# Altium schematic sheet CPU.SchDoc
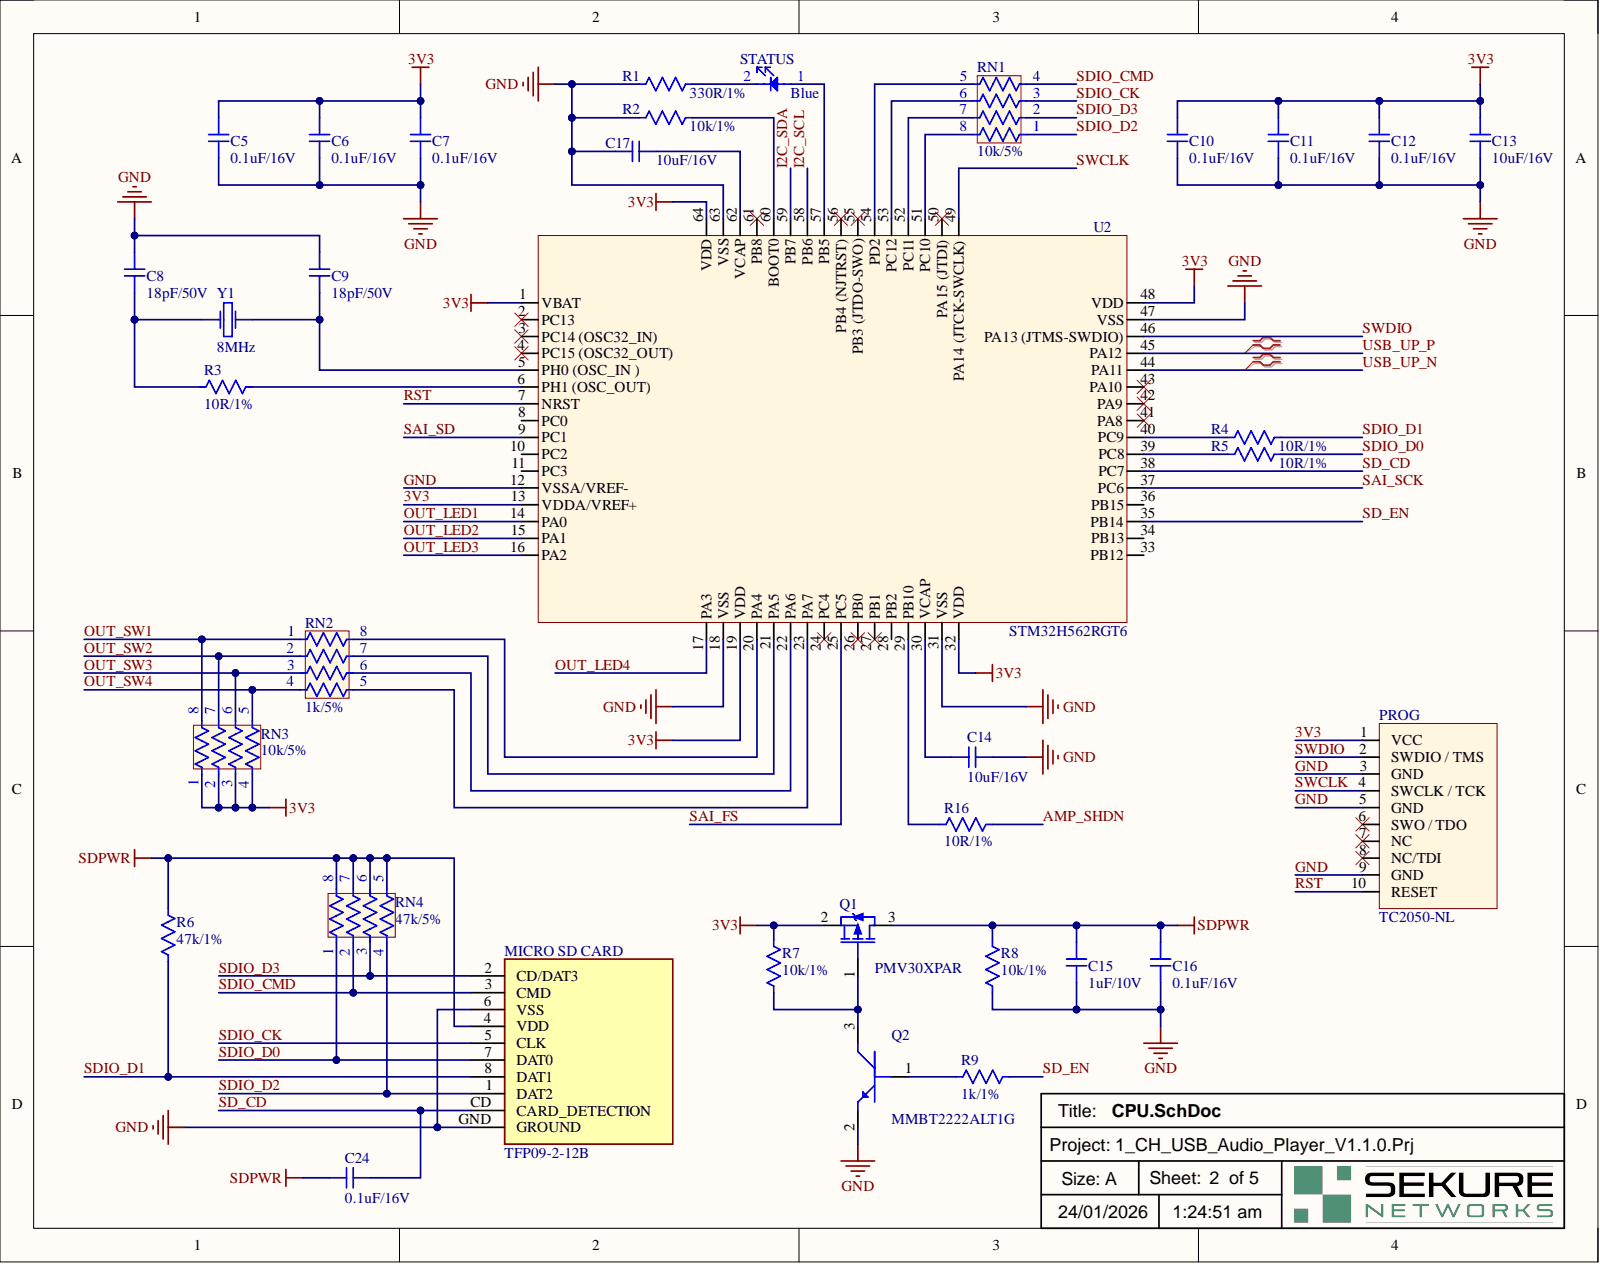
[diagram p0: CPU.SchDoc]

## Parts
C5  value 0.1uF/16V  [CAP 0603]  (hidden comment: Ceramic Capacitor)
C6  value 0.1uF/16V  [CAP 0603]  (hidden comment: Ceramic Capacitor)
C7  value 0.1uF/16V  [CAP 0603]  (hidden comment: Ceramic Capacitor)
C8  value 18pF/50V  [CAP 0603]  (hidden comment: Ceramic Capacitor)
C9  value 18pF/50V  [CAP 0603]  (hidden comment: Ceramic Capacitor)
C10  value 0.1uF/16V  [CAP 0603]  (hidden comment: Ceramic Capacitor)
C11  value 0.1uF/16V  [CAP 0603]  (hidden comment: Ceramic Capacitor)
C12  value 0.1uF/16V  [CAP 0603]  (hidden comment: Ceramic Capacitor)
C13  value 10uF/16V  [Cap 0805]  (hidden comment: Ceramic Capacitor)
C14  value 10uF/16V  [Cap 0805]  (hidden comment: Ceramic Capacitor)
C15  value 1uF/10V  [CAP 0603]  (hidden comment: Ceramic Capacitor)
C16  value 0.1uF/16V  [CAP 0603]  (hidden comment: Ceramic Capacitor)
C17  value 10uF/16V  [Cap 0805]  (hidden comment: Ceramic Capacitor)
C24  value 0.1uF/16V  [CAP 0603]  (hidden comment: Ceramic Capacitor)
MICRO_SD_CARD  value TFP09-2-12B  [MR01A-01211]  (hidden comment: SD Card Slot)
PROG  value TC2050-NL  [TC2050_full]  (hidden comment: Programming Connector)
Q1  value PMV30XPAR  [SOT23]  (hidden comment: Mosfet)
Q2  value MMBT2222ALT1G  [SOT23]  (hidden comment: Transistor)
R1  value 330R/1%  [RES 0603]  (hidden comment: Resistor)
R2  value 10k/1%  [RES 0603]  (hidden comment: Resistor)
R3  value 10R/1%  [RES 0603]  (hidden comment: Resistor)
R4  value 10R/1%  [RES 0603]  (hidden comment: Resistor)
R5  value 10R/1%  [RES 0603]  (hidden comment: Resistor)
R6  value 47k/1%  [RES 0603]  (hidden comment: Resistor)
R7  value 10k/1%  [RES 0603]  (hidden comment: Resistor)
R8  value 10k/1%  [RES 0603]  (hidden comment: Resistor)
R9  value 1k/1%  [RES 0603]  (hidden comment: Resistor)
R16  value 10R/1%  [RES 0603]  (hidden comment: Resistor)
RN1  value 10k/5%  [1206 (3216 Metric)]  (hidden comment: Resistor Network)
RN2  value 1k/5%  [1206 (3216 Metric)]  (hidden comment: Resistor Network)
RN3  value 10k/5%  [1206 (3216 Metric)]  (hidden comment: Resistor Network)
RN4  value 47k/5%  [1206 (3216 Metric)]  (hidden comment: Resistor Array)
STATUS  value Blue  Color: Blue  [APT1608SURCK]  (hidden comment: LED)
U2  value STM32H562RGT6  [LQFP64-1000X1000X160-64N]  (hidden comment: Microcontroller)
Y1  value 8MHz  Frequency: 8MHz  [ECS-80-18-30-JGN-TR]  (hidden comment: Crystal)

## Nets
SDPWR: C15.2 C16.1 C24.1 MICRO_SD_CARD.4(VDD) Q1.3 R6.1 R8.2 RN4.5 RN4.6 RN4.7 RN4.8
3V3: C5.1 C6.1 C7.1 C10.1 C11.1 C12.1 C13.1 PROG.1(VCC) Q1.2 R7.2 RN3.1 RN3.2 RN3.3 RN3.4 U2.1(VBAT) U2.13(VDDA/VREF+) U2.19(VDD) U2.32(VDD) U2.48(VDD) U2.64(VDD)
AMP_SHDN: R16.2
GND: C5.2 C6.2 C7.2 C8.1 C9.1 C10.2 C11.2 C12.2 C13.2 C14.2 C15.1 C16.2 C17.2 MICRO_SD_CARD.6(VSS) MICRO_SD_CARD.GND(GROUND) PROG.3(GND) PROG.5(GND) PROG.9(GND) Q2.2 R1.2 R2.2 R8.1 U2.12(VSSA/VREF-) U2.18(VSS) U2.31(VSS) U2.47(VSS) U2.63(VSS)
I2C_SCL: U2.58(PB6)
I2C_SDA: U2.59(PB7)
OUT_LED1: U2.14(PA0)
OUT_LED2: U2.15(PA1)
OUT_LED3: U2.16(PA2)
OUT_LED4: U2.17(PA3)
OUT_SW1: RN2.1 RN3.8
OUT_SW2: RN2.2 RN3.7
OUT_SW3: RN2.3 RN3.6
OUT_SW4: RN2.4 RN3.5
RST: PROG.10(RESET) U2.7(NRST)
SAI_FS: U2.25(PC5)
SAI_SCK: U2.37(PC6)
SAI_SD: U2.9(PC1)
SD_CD: C24.2 MICRO_SD_CARD.CD(CARD_DETECTION) U2.38(PC7)
SD_EN: R9.1 U2.35(PB14)
SDIO_CK: MICRO_SD_CARD.5(CLK) RN1.3
SDIO_CMD: MICRO_SD_CARD.3(CMD) RN1.4 RN4.2
SDIO_D0: MICRO_SD_CARD.7(DAT0) R5.2 RN4.1
SDIO_D1: MICRO_SD_CARD.8(DAT1) R4.2 R6.2
SDIO_D2: MICRO_SD_CARD.1(DAT2) RN1.1 RN4.4
SDIO_D3: MICRO_SD_CARD.2(CD/DAT3) RN1.2 RN4.3
SWCLK: PROG.4(SWCLK_/_TCK) U2.49(PA14_(JTCK-SWCLK))
SWDIO: PROG.2(SWDIO_/_TMS) U2.46(PA13_(JTMS-SWDIO))
USB_UP_N: U2.44(PA11)
USB_UP_P: U2.45(PA12)
NetC8_2: C8.2 R3.1 Y1.1
NetC9_2: C9.2 U2.5(PH0_(OSC_IN_)) Y1.2
NetC14_1: C14.1 U2.30(VCAP)
NetC17_1: C17.1 U2.62(VCAP)
NetQ1_1: Q1.1 Q2.3 R7.1
NetQ2_1: Q2.1 R9.2
NetR1_1: R1.1 STATUS.2
NetR2_1: R2.1 U2.60(BOOT0)
NetR3_2: R3.2 U2.6(PH1_(OSC_OUT))
NetR4_1: R4.1 U2.40(PC9)
NetR5_1: R5.1 U2.39(PC8)
NetR16_1: R16.1 U2.29(PB10)
NetRN1_5: RN1.5 U2.54(PD2)
NetRN1_6: RN1.6 U2.53(PC12)
NetRN1_7: RN1.7 U2.52(PC11)
NetRN1_8: RN1.8 U2.51(PC10)
NetRN2_5: RN2.5 U2.23(PA7)
NetRN2_6: RN2.6 U2.22(PA6)
NetRN2_7: RN2.7 U2.21(PA5)
NetRN2_8: RN2.8 U2.20(PA4)
NetSTATUS_1: STATUS.1 U2.57(PB5)

Not connected: PROG 6(SWO_/_TDO) 7(NC) 8(NC/TDI); U2 2(PC13) 3(PC14_(OSC32_IN)) 4(PC15_(OSC32_OUT)) 8(PC0) 10(PC2) 11(PC3) 24(PC4) 26(PB0) 27(PB1) 28(PB2) 33(PB12) 34(PB13) 36(PB15) 41(PA8) 42(PA9) 43(PA10) 50(PA15_(JTDI)) 55(PB3_(JTDO-SWO)) 56(PB4_(NJTRST)) 61(PB8)
